# Altium schematic sheet lorawan.SchDoc
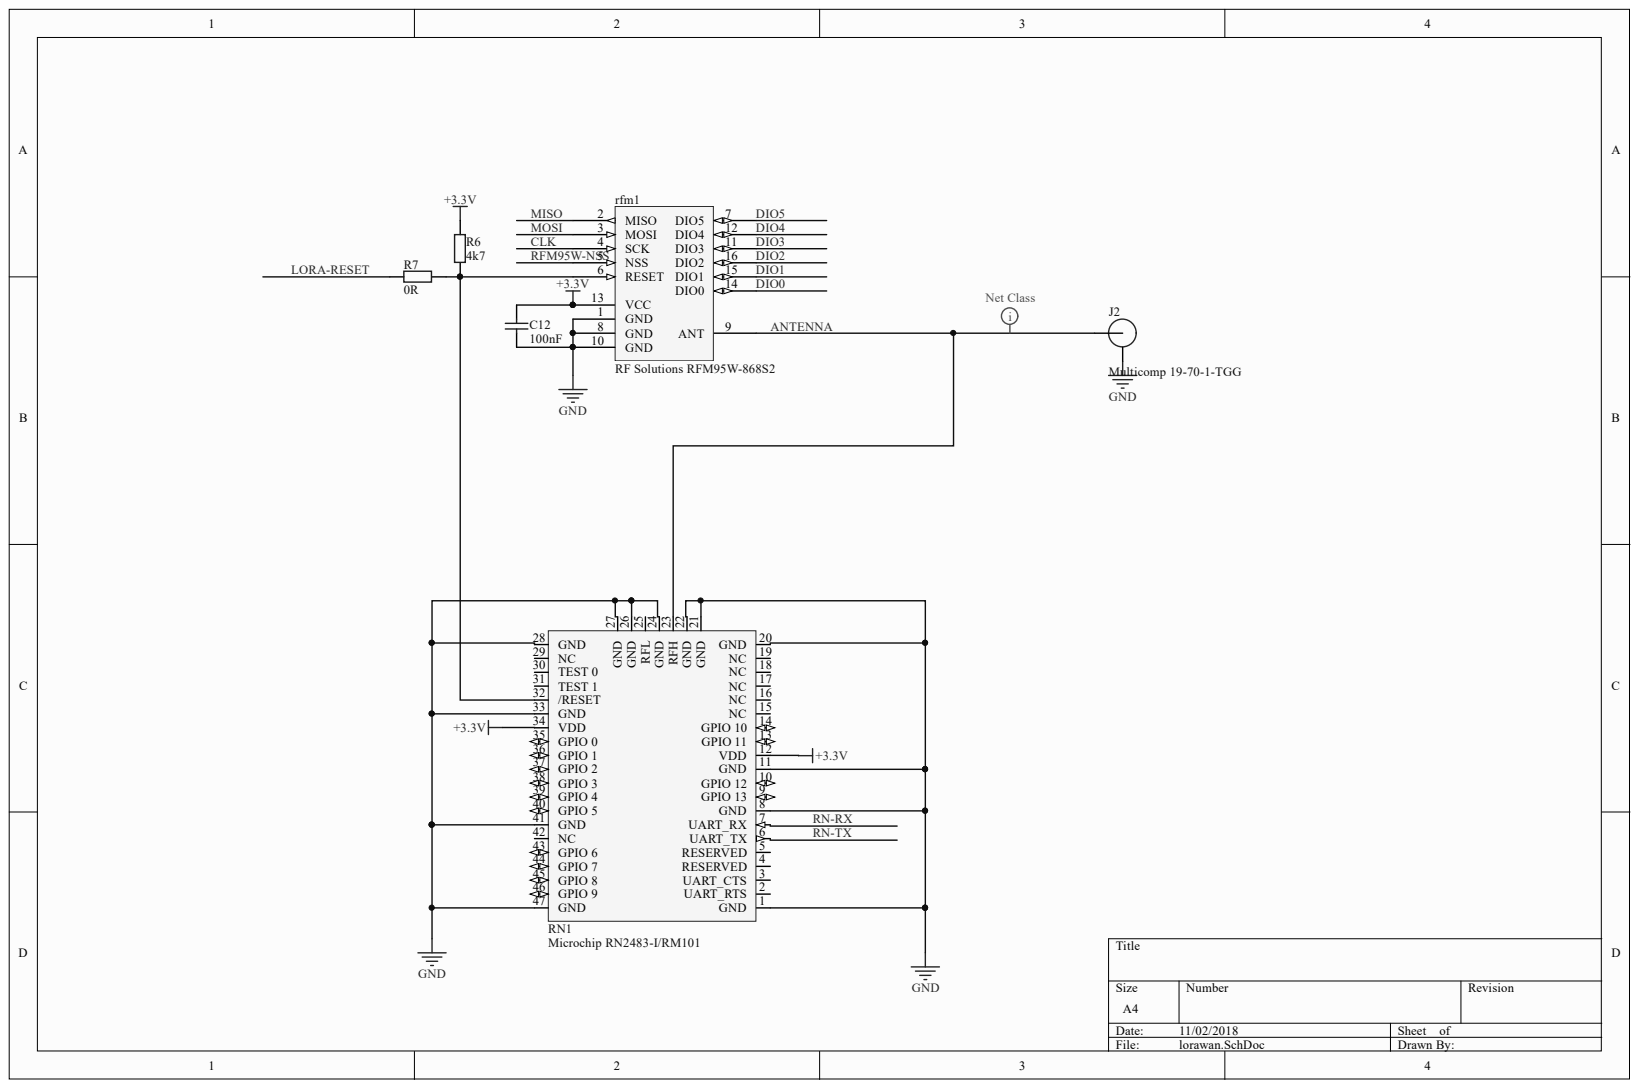
[diagram p0: lorawan.SchDoc]

## Parts
C12  100nF  [PCB-14j6yrx10pagyq47cjuv-1]
J2  Multicomp 19-70-1-TGG  [PCB-319hzbhc3utknsftgyak-1]
R6  4k7  [PCB-1vayfl3026fycqkmw7iz-1]
R7  0R  [PCB-ft0dcd0ut8iq5myyq8j7-1]
rfm1  RF Solutions RFM95W-868S2  Manufacturer Part Number: RFM95W-868S2  [PCB-2a6x3qap8y64pm23m1ij-1]
RN1  Microchip RN2483-I/RM101  Manufacturer Part Number: RN2483-I/RM101  [PCB-zt6psclehwyhi64tgtg2-1]

## Nets
+3.3V: C12.2 R6.2 rfm1.13(VCC) RN1.12(VDD) RN1.34(VDD)
GND: C12.1 J2.GND rfm1.1(GND) rfm1.8(GND) rfm1.10(GND) RN1.1(GND) RN1.8(GND) RN1.11(GND) RN1.20(GND) RN1.21(GND) RN1.22(GND) RN1.24(GND) RN1.26(GND) RN1.27(GND) RN1.28(GND) RN1.33(GND) RN1.41(GND) RN1.47(GND)
ANTENNA: J2.SIG rfm1.9(ANT) RN1.23(RFH)
CLK: rfm1.4(SCK)
DIO0: rfm1.14(DIO0)
DIO1: rfm1.15(DIO1)
DIO2: rfm1.16(DIO2)
DIO3: rfm1.11(DIO3)
DIO4: rfm1.12(DIO4)
DIO5: rfm1.7(DIO5)
LORA-RESET: R7.1
MISO: rfm1.2(MISO)
MOSI: rfm1.3(MOSI)
RFM95W-NSS: rfm1.5(NSS)
RN-RX: RN1.7(UART_RX)
RN-TX: RN1.6(UART_TX)
NetR6_1: R6.1 R7.2 rfm1.6(RESET) RN1.32(/RESET)

Not connected: RN1 2(UART_RTS) 3(UART_CTS) 4(RESERVED) 5(RESERVED) 9(GPIO_13) 10(GPIO_12) 13(GPIO_11) 14(GPIO_10) 15(NC) 16(NC) 17(NC) 18(NC) 19(NC) 25(RFL) 29(NC) 30(TEST_0) 31(TEST_1) 35(GPIO_0) 36(GPIO_1) 37(GPIO_2) 38(GPIO_3) 39(GPIO_4) 40(GPIO_5) 42(NC) 43(GPIO_6) 44(GPIO_7) 45(GPIO_8) 46(GPIO_9)

## Library data of the parts
C12 = CMP-cab80115e1b0157f-1: 0603 1 uF 10 V ±10 % Tol. X5R Surface Mount Multilayer Ceramic Capacitor; Capacitance Tolerance: ±10%; Lead-Free Status: Lead Free; Voltage Rating (DC): 10.0 V; Case/Package: 0603; Component Kind: Standard; Dielectric Characteristic: X5R; Library Name: CommunityVault; Mounting Style: Surface Mount; OctopartID: cab80115e1b0157f; Octopart URL: http://octopart.com/0603x105k100ct-walsin+technologies-44502638; Packaging: Cut Tape (CT); RoHS: Compliant
J2 = CMP-cdb104e8e6b51276-4: SMA Connector; Connector Type: SMA Coaxial; Impedance: 50ohm; Contact Material: Beryllium Copper; Contact Plating: Go...; Insulation Resistance: 5.00 GΩ; Number of Mating Cycles: 500; Component Kind: Standard; Library Name: CommunityVault; Manufacturer Part Number: 19-70-1-TGG; Material: Brass; Mounting Style: PCB; RoHS: Compliant
R6 = CMP-91545b5de2944e93-1: Surface Mount Thick Film Resistor, MCWR Series, 4.7 kohm, 100 mW, - 1%, 50 V; Manufacturer Part Number: MCWR06X4701FTL; Case/Package (SI): 1608; Power Rating: 100 mW; Resistance: 4.70 kΩ; Case/Package: 0603, 1608; Component Kind: Standard; Library Name: CommunityVault; OctopartID: 91545b5de2944e93; Octopart URL: http://octopart.com/mcwr06x4701ftl-multicomp-50550438; Packaging: Tape & Reel (TR), Cut Tape (CT); REACH SVHC Compliance: No SVHC; Resistance Tolerance: ±1%; RoHS: Compliant
R7 = CMP-56f49cfd25b78933-1: Surface Mount Chip Resistor, Thick Film, MCWR Series, 0 ohm, 100 mW, 0603 [1608 Metric]; Power Rating: 100 mW; Resistance: 0.00 Ω; Voltage Rating (DC): 50.0 V; Case/Package: 0603, 1608; Component Kind: Standard; Library Name: CommunityVault; OctopartID: 56f49cfd25b78933; Octopart URL: http://octopart.com/mcwr06x000+ptl-multicomp-50550309; Packaging: Cut Tape (CT), Tape & Reel (TR)
rfm1 = CMP-d0331a1d54b00ff4-3: Module: RF; FM transceiver; FSK; 868MHz; -139dBm; 1.8÷3.7VDC; 20d; Component Kind: Standard; Library Name: CommunityVault; OctopartID: d0331a1d54b00ff4; Octopart URL: http://octopart.com/rfm95w-868s2-rf+solutions-52732389
RN1 = CMP-2b75e6431462f4b2-3: Low-Power Long Range LoRa® Technology Radio Module; Lead-Free Status: Lead Free; Supply Current: 38.9 mA; Supply Voltage (DC): 2.10 V (min); Component Kind: Standard; Library Name: FavoritesLibrary; Lifecycle Status: Not Listed by Manufacturer; OctopartID: 2b75e6431462f4b2; Octopart URL: http://octopart.com/rn2483-i%2Frm101-microchip-71047793; RoHS: Compliant
